# Altium schematic sheet 光源机计时器.SchDoc
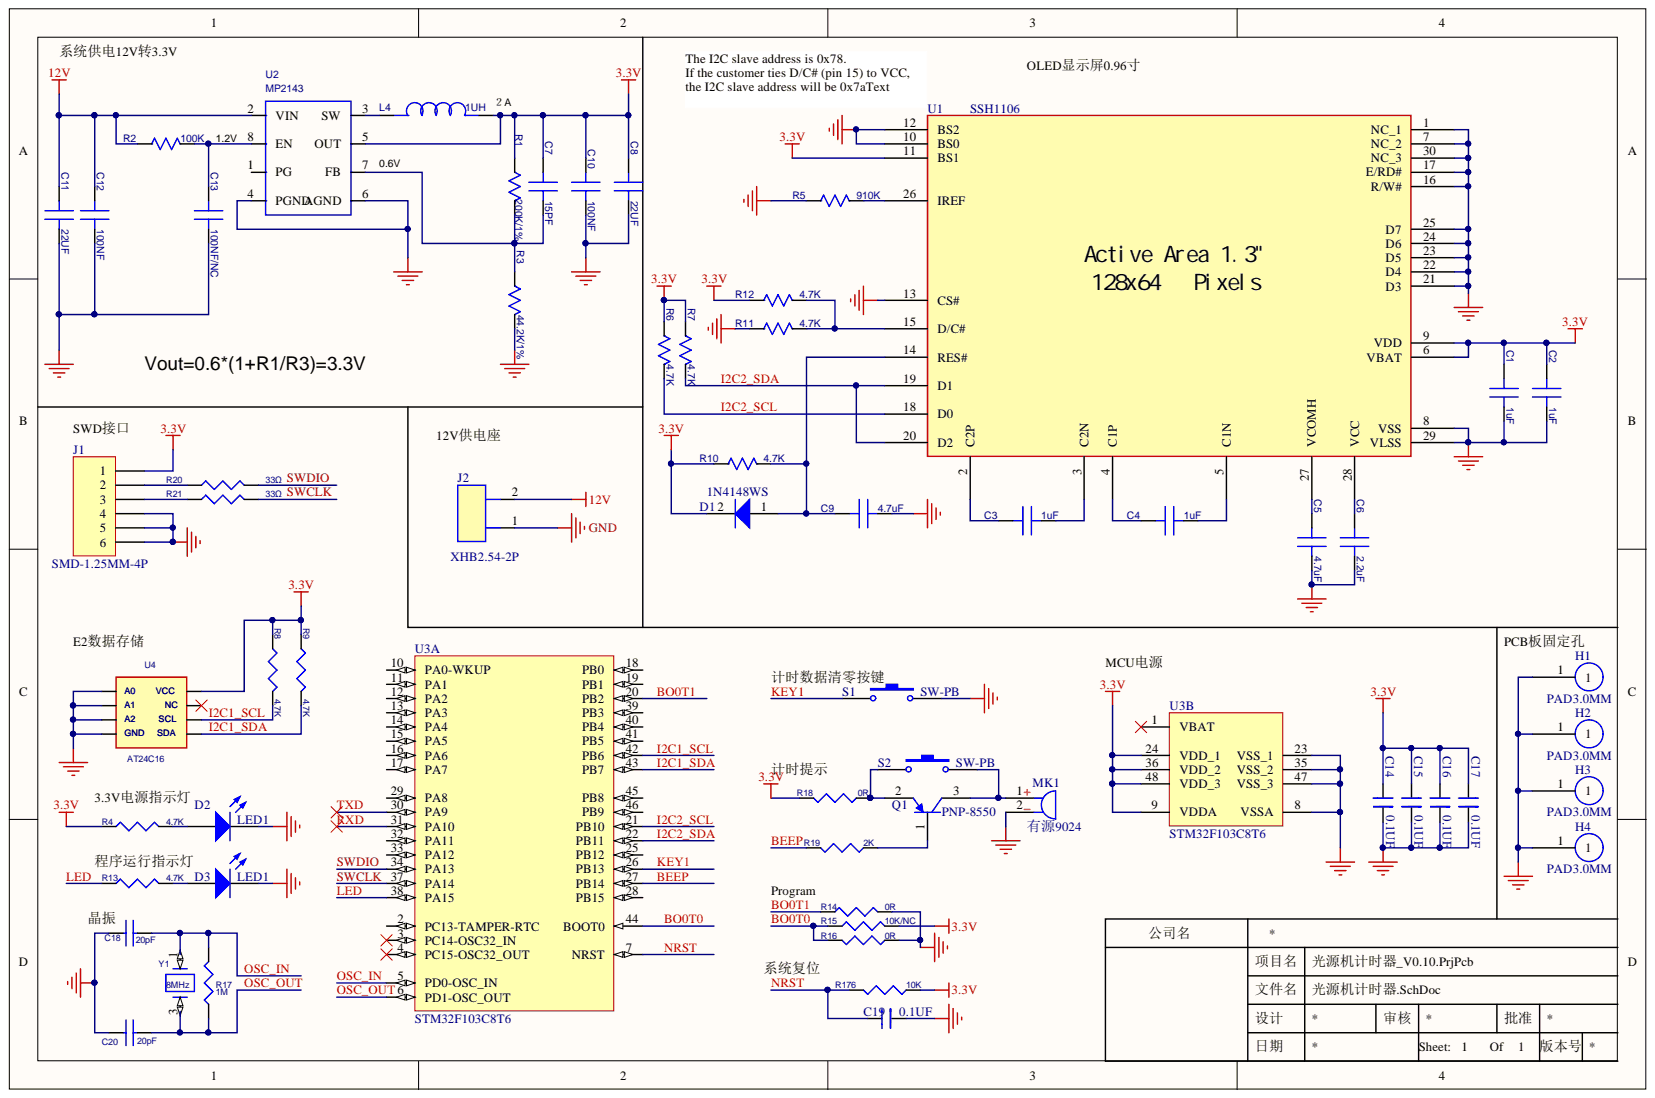
[diagram p0: 光源机计时器.SchDoc]

## Parts
C1  1uF  [0603C]
C2  1uF  [0603C]
C3  1uF  [0603C]
C4  1uF  [0603C]
C5  4.7uF  [0603C]
C6  2.2uF  [0603C]
C7  15PF  [0603C]
C8  22UF  [C0805]
C9  4.7uF  [0603C]
C10  100NF  [0603C]
C11  22UF  [C0805]
C12  100NF  [0603C]
C13  100NF/NC  [0603C]
C14  0.1UF  [0603C]
C15  0.1UF  [0603C]
C16  0.1UF  [0603C]
C17  0.1UF  [0603C]
C18  20pF  [0603C]
C19  0.1UF  [0603C]
C20  20pF  [0603C]
D1  1N4148WS  [SOD323]
D2  LED1  [0603-LED_SMD]
D3  LED1  [0603-LED_SMD]
H1  PAD3.0MM  [3mm]
H2  PAD3.0MM  [3mm]
H3  PAD3.0MM  [3mm]
H4  PAD3.0MM  [3mm]
J1  SMD-1.25MM-4P  [SDM-XH1.25MM-4P]
J2  XHB2.54-2P  [DIP2_2.54mm]
L4  1UH  [SPL0404B]
MK1  有源9024  [hummer 9*2.4]
Q1  PNP-8550  [SOT-23B_N]
R1  200K/1%  [0603R]
R2  100K  [0603R]
R3  44.2K/1%  [0603R]
R4  4.7K  [0603R]
R5  910K  [0603R]
R6  4.7K  [0603R]
R7  4.7K  [0603R]
R8  4.7K  [0603R]
R9  4.7K  [0603R]
R10  4.7K  [0603R]
R11  4.7K  [0603R]
R12  4.7K  [0603R]
R13  4.7K  [0603R]
R14  0R  [0603R]
R15  10K/NC  [0603R]
R16  0R  [0603R]
R17  1M  [0603R]
R18  0R  [0603R]
R19  2K  [0603R]
R20  33Ω  [0603R]
R21  33Ω  [0603R]
R176  10K  [0603R]
S1  SW-PB  [SMD-5*5*1.5]
S2  SW-PB  [SMD-5*5*1.5]
U1  SSH1106  [OLED1.3_P0.7-W0.4]
U2  DEVICE: MP2143  [TSOT23-8]  (hidden comment: MP2161GJ)
U3  STM32F103C8T6  [STM-LQFP48_N]  (parts A,B)
U4  AT24C16  [SO8_N]
Y1  8MHz  [OSC3225]

## Nets
3.3V: C1.1 C2.1 C7.1 C8.1 C10.1 C14.2 C15.2 C16.2 C17.2 D1.2 J1.1 L4.2 R1.1 R4.1 R6.1 R7.1 R8.1 R9.1 R10.1 R12.1 R15.2 R18.2 R176.1 U1.6(VBAT) U1.9(VDD) U1.11(BS1) U2.5(OUT) U3.9(VDDA) U3.24(VDD_1) U3.36(VDD_2) U3.48(VDD_3) U4.8(VCC)
12V: C11.1 C12.1 J2.2 R2.1 U2.2(VIN)
GND: C1.2 C2.2 C5.2 C6.2 C8.2 C9.2 C10.2 C11.2 C12.2 C13.2 C14.1 C15.1 C16.1 C17.1 C18.1 C19.1 C20.1 D2.2 D3.2 H1.1 H2.1 H3.1 H4.1 J1.4 J1.5 J1.6 J2.1 MK1.2 R3.2 R5.1 R11.1 R14.2 R16.1 S1.2 U1.1(NC_1) U1.7(NC_2) U1.8(VSS) U1.10(BS0) U1.12(BS2) U1.13(CS#) U1.16(R/W#) U1.17(E/RD#) U1.21(D3) U1.22(D4) U1.23(D5) U1.24(D6) U1.25(D7) U1.29(VLSS) U1.30(NC_3) U2.4(PGND) U2.6(AGND) U3.8(VSSA) U3.23(VSS_1) U3.35(VSS_2) U3.47(VSS_3) U4.1(A0) U4.2(A1) U4.3(A2) U4.4(GND) Y1.2 Y1.4
BEEP: R19.2 U3.27(PB14)
BO0T0: R15.1 R16.2 U3.44(BOOT0)
BO0T1: R14.1 U3.20(PB2)
I2C1_SCL: R8.2 U3.42(PB6) U4.6(SCL)
I2C1_SDA: R9.2 U3.43(PB7) U4.5(SDA)
I2C2_SCL: R6.2 U1.18(D0) U3.21(PB10)
I2C2_SDA: R7.2 U1.19(D1) U1.20(D2) U3.22(PB11)
KEY1: S1.1 U3.26(PB13)
LED: R13.1 U3.38(PA15)
NRST: C19.2 R176.2 U3.7(NRST)
OSC_IN: C18.2 R17.2 U3.5(PD0-OSC_IN) Y1.1
OSC_OUT: C20.2 R17.1 U3.6(PD1-OSC_OUT) Y1.3
RXD: U3.31(PA10)
SWCLK: R21.1 U3.37(PA14)
SWDIO: R20.1 U3.34(PA13)
TXD: U3.30(PA9)
NetC3_1: C3.1 U1.2(C2P)
NetC3_2: C3.2 U1.3(C2N)
NetC4_1: C4.1 U1.4(C1P)
NetC4_2: C4.2 U1.5(C1N)
NetC5_1: C5.1 U1.27(VCOMH)
NetC6_1: C6.1 U1.28(VCC)
NetC7_2: C7.2 R1.2 R3.1 U2.7(FB)
NetC9_1: C9.1 D1.1 R10.2 U1.14(RES#)
NetC13_1: C13.1 R2.2 U2.8(EN)
NetD2_1: D2.1 R4.2
NetD3_1: D3.1 R13.2
NetJ1_2: J1.2 R20.2
NetJ1_3: J1.3 R21.2
NetL4_1: L4.1 U2.3(SW)
NetMK1_1: MK1.1 Q1.3 S2.2
NetQ1_1: Q1.1 R19.1
NetQ1_2: Q1.2 R18.1 S2.1
NetR5_2: R5.2 U1.26(IREF)
NetR11_2: R11.2 R12.2 U1.15(D/C#)

Not connected: U2 1(PG); U3 1(VBAT) 2(PC13-TAMPER-RTC) 3(PC14-OSC32_IN) 4(PC15-OSC32_OUT) 10(PA0-WKUP) 11(PA1) 12(PA2) 13(PA3) 14(PA4) 15(PA5) 16(PA6) 17(PA7) 18(PB0) 19(PB1) 25(PB12) 28(PB15) 29(PA8) 32(PA11) 33(PA12) 39(PB3) 40(PB4) 41(PB5) 45(PB8) 46(PB9); U4 7(NC)

## Library data of the parts
C1-C6 C9 = C_0: PARTS: 1; %182%%238%%182%%168%%185%%164%%215%%247%%181%%231%%209%%185%(V): 50; %195%%232%%202%%246%: SMD陶瓷电容-50V-100NF-+/-10%-X7R-0603; %208%%161%%192%%224%%195%%251%%179%%198%: SMD陶瓷电容; CODE: 8070627; COMPONENT_HIGH_MAX: 0.9MM; DEVICE: C1608X7R1H104KT000N; LEVEL: STD; OriginalSymbolOrigin: (0,0); PINSWAP: (A,B); PKG_TYPE: SC0603; Component Kind: Standard
C7 = C_0: PARTS: 1; %182%%238%%182%%168%%185%%164%%215%%247%%181%%231%%209%%185%(V): 50; %195%%232%%202%%246%: SMD陶瓷电容-50V-0.015NF-+/-5%-NP0-0402; %208%%161%%192%%224%%195%%251%%179%%198%: SMD陶瓷电容; CODE: 8070544; COMPONENT_HIGH_MAX: 0.55MM; DEVICE: C0402C150J5GAC_7867; LEVEL: STD; OriginalSymbolOrigin: (0,0); PINSWAP: (A,B); PKG_TYPE: SC0402; Component Kind: Standard
C8 = C_0: PARTS: 1; %182%%238%%182%%168%%185%%164%%215%%247%%181%%231%%209%%185%(V): 6.3; %195%%232%%202%%246%: SMD陶瓷电容-6.3V-22000NF-+/-20%-X5R-0805-08070784编码拆分供华为技术使用; %208%%161%%192%%224%%195%%251%%179%%198%: SMD陶瓷电容; CODE: 8071114; COMPONENT_HIGH_MAX: 1.35MM; DEVICE: C2012X5R0J226MT000N; LEVEL: STD; OriginalSymbolOrigin: (0,0); PINSWAP: (A,B); PKG_TYPE: SC0805; Component Kind: Standard
C10 = C_0: PARTS: 1; %182%%238%%182%%168%%185%%164%%215%%247%%181%%231%%209%%185%(V): 16; %195%%232%%202%%246%: SMD陶瓷电容-16V-100NF-+/-10%-X7R-0402-BT; %208%%161%%192%%224%%195%%251%%179%%198%: SMD陶瓷电容; CODE: 8070614; COMPONENT_HIGH_MAX: 0.6MM; DEVICE: RMEMK105BJ104KV_FR; LEVEL: STD; OriginalSymbolOrigin: (0,0); PINSWAP: (A,B); PKG_TYPE: SC0402; Component Kind: Standard
C11 = C_0: PARTS: 1; %182%%238%%182%%168%%185%%164%%215%%247%%181%%231%%209%%185%(V): 6.3; %195%%232%%202%%246%: SMD陶瓷电容-6.3V-22000nF-+/-20%-X5R-0805-08070784编码拆分供华为技术使用; %208%%161%%192%%224%%195%%251%%179%%198%: SMD陶瓷电容; CODE: 8071114; COMPONENT_HIGH_MAX: 1.4mm; DEVICE: C2012X5R0J226MT000N; LEVEL: STD; OriginalSymbolOrigin: (0,0); PINSWAP: (A,B); PKG_TYPE: SC0805; Component Kind: Standard
C12 = C_0: PARTS: 1; %182%%238%%182%%168%%185%%164%%215%%247%%181%%231%%209%%185%(V): 10; %195%%232%%202%%246%: SMD陶瓷电容-10V-100nF-+/-10%-X5R-0402; %208%%161%%192%%224%%195%%251%%179%%198%: SMD陶瓷电容; CODE: 8070561; COMPONENT_HIGH_MAX: 0.55MM; DEVICE: C0402C104K8PAC_7867; LEVEL: STD; OriginalSymbolOrigin: (0,0); PINSWAP: (A,B); PKG_TYPE: SC0402; Component Kind: Standard
C13 = C_0: PARTS: 1; %182%%238%%182%%168%%185%%164%%215%%247%%181%%231%%209%%185%(V): 16; %195%%232%%202%%246%: SMD陶瓷电容-16V-100NF-+/-10%-X7R-0402-BT; %208%%161%%192%%224%%195%%251%%179%%198%: SMD陶瓷电容; CODE: 8070614; COMPONENT_HIGH_MAX: 0.55MM; DEVICE: RMEMK105BJ104KV_FR; LEVEL: STD; OriginalSymbolOrigin: (0,0); PINSWAP: (A,B); PKG_TYPE: SC0402; Component Kind: Standard
C14-C17 C19 = CAP2: 陶瓷电容; Component Kind: Standard; Library Name: 原理图元件.SCHLIB.Lib
C18 C20 = C_Dup1: EDIForiginalOrigin: (pt 0 0); EDIFviewName: C_NORMAL; Implementation Type: <none>; Number devices in Package: 1; Pin Names Rotate: False; PositionInPackage: 0; Source Package: C; Component Kind: Standard; Pin Names Visible: False; Pin Numbers Visible: False
D1 = Diode: Default Diode; Component Kind: Standard; Library Name: Miscellaneous Devices.IntLib
D2 D3 = LED2: Typical RED, GREEN, YELLOW, AMBER GaAs LED; Component Kind: Standard; Library Name: Miscellaneous Devices.IntLib
J1 = Header 6: Header, 6-Pin; Component Kind: Standard; Library Name: Miscellaneous Connectors.IntLib
J2 = 2P: Component Kind: Standard; Library Name: 自己元件.SCHLIB.Lib
L4 = L_1: PARTS: 1; %195%%232%%202%%246%: 贴片电感器-1UH-30%-0.0324OHM-4A-40DEGC-4*4*2MM; %208%%161%%192%%224%%195%%251%%179%%198%: 贴片电感器; CODE: 10031357; COMPONENT_HIGH_MAX: 2.1MM; DEVICE: NRS4018T1R0NDGJ; LEVEL: STD; OriginalSymbolOrigin: (-20,0); Component Kind: Standard; PKG_TYPE: SPL0404B
MK1 = Mic1: Microphone; Component Kind: Standard; Library Name: Miscellaneous Devices.IntLib
Q1 = PNP: PNP Bipolar Transistor; Component Kind: Standard; Library Name: Miscellaneous Devices.IntLib
R1 R3 = R_0: PARTS: 1; %182%%238%%182%%168%%185%%166%%194%%202%(W): 0.1; %195%%232%%202%%246%: 片式厚膜电阻器-0.1W-200000OHM-+/-1%-0603; %208%%161%%192%%224%%195%%251%%179%%198%: 片式厚膜电阻器; %215%%232%%214%%181%%212%%202%%208%%237%%198%%171%%178%%238%: +/-1%; CODE: 7091263; COMPONENT_HIGH_MAX: 0.55MM; DEVICE: RK73H1JTTD2003F; LEVEL: STD; OriginalSymbolOrigin: (0,0); PINSWAP: (A,B); PKG_TYPE: SR0603; Component Kind: Standard
R2 = R_0: PARTS: 1; %182%%238%%182%%168%%185%%166%%194%%202%(W): 0.06; %195%%232%%202%%246%: 片式厚膜电阻器-0.0625W-100000OHM-+/-1%-0402; %208%%161%%192%%224%%195%%251%%179%%198%: 片式厚膜电阻器; %215%%232%%214%%181%%212%%202%%208%%237%%198%%171%%178%%238%: +/-1%; CODE: 7090875; COMPONENT_HIGH_MAX: 0.4MM; DEVICE: RK73H1ETTP1003F; LEVEL: STD; OriginalSymbolOrigin: (0,0); PINSWAP: (A,B); PKG_TYPE: SR0402; Component Kind: Standard
R4 R8 R9 R13-R17 R176 = R_Dup2: EDIForiginalOrigin: (pt 0 0); EDIFviewName: R_NORMAL; Implementation Type: <none>; Number devices in Package: 1; Pin Names Rotate: True; Pin Names Visible: False; PositionInPackage: 0; Source Package: R; Component Kind: Standard; Pin Numbers Visible: False
R5-R7 R10-R12 = R_0: PARTS: 1; %182%%238%%182%%168%%185%%166%%194%%202%(W): 0.1; %195%%232%%202%%246%: 片式厚膜电阻器-0.1W-33200OHM-+/-1%-0603; %208%%161%%192%%224%%195%%251%%179%%198%: 片式厚膜电阻器; %215%%232%%214%%181%%212%%202%%208%%237%%198%%171%%178%%238%: +/-1%; CODE: 7090766; COMPONENT_HIGH_MAX: 0.55MM; DEVICE: RC0603FR_0733K2; LEVEL: STD; OriginalSymbolOrigin: (0,0); PINSWAP: (A,B); PKG_TYPE: SR0603; Component Kind: Standard
R18 R19 = R_Dup2: Component Kind: Standard
R20 R21 = R_Dup5: Component Kind: Standard
S1 S2 = SW-PB: Switch; Component Kind: Standard; LatestRevisionDate: 17-Jul-2002; LatestRevisionNote: Re-released for DXP Platform.; Library Name: Miscellaneous Devices.IntLib; PackageDescription: Switch; 2 Leads; PackageReference: SPST-2; Published: 24-Mar-1999; Publisher: Altium Limited
U1 = SSD1306: Component Kind: Standard; Library Name: 光源机计时器.SchLib
U2 = MP2161GJ_0: PARTS: 1; %195%%232%%202%%246%: MP2161GJ-01754725; CODE: 99054LFT; COMPONENT_HIGH_MAX: 1MM; LEVEL: STD; OriginalSymbolOrigin: (0,0); Component Kind: Standard; PKG_TYPE: TSOT23-8
U3 = STM32F103C8T6: ARM Cortex-M3 32-bit MCU, 64 KB Flash, 20 KB Internal RAM, 37 I/Os, 48-pin LQFP, -40 to 85 degC, Tray; A/D Converter: 2x12-bit (10 Channel); FLASH Size (Prog) (kB): 64; I/Os (High Current): 37; Internal RAM Size (kB): 20; Operating Frequency(F) (Processor speed) (MHz): 72; Supply Voltage(Vcc) min (V): 2; Component Kind: Standard; ComponentLink1Description: Manufacturer URL; ComponentLink1URL: http://www.st.com/web/en/home.html; ComponentLink2Description: Datasheet; ComponentLink2URL: http://www.st.com/st-web-ui/static/active/en/resource/technical/document/datasheet/CD00161566.pdf; ComponentLink3Description: Package Specification; ComponentLink3URL: http://www.st.com/st-web-ui/static/active/en/resource/technical/document/datasheet/DM00058181.pdf; Core: ARM Cortex-M3; DatasheetVersion: Rev. 16; Library Name: STMicroelectronics STM32 F1.IntLib; Mounting Technology: Surface Mount; PackageDescription: 48-Pin Low Profile Quad Flat Package, 7 x 7 mm Body, 0.5 mm Pitch; PackageReference: LQFP48; PackageVersion: Rev. 6, 06/2013; Packing: Tray; PartNumber: STM32F103C8T6; Supplier 1: Digi-Key; Supplier 2: Farnell; Supplier 3: Newark; Supplier 4: Newark; Supplier 5: Mouser; Supplier Part Number 1: 497-6063-ND; Supplier Part Number 2: 1447637; Supplier Part Number 3: 59M2182; Supplier Part Number 4: 57P1222; Supplier Part Number 5: 511-STM32F103C8T6
U4 = AT24C02: EDIForiginalOrigin: (pt 0 0); EDIFviewName: AT24C02_NORMAL; Implementation Type: <none>; Number devices in Package: 1; Pin Names Rotate: True; PositionInPackage: 0; Component Kind: Standard; Pin Names Visible: True; Pin Numbers Visible: True; Source Package: AT24C02
Y1 = CRYSTAL_4PIN: Part Description: 4 pin, passive; Designer: Hujin; Component Kind: Standard; Create Date: 20130122; Part Number: 647-00-3038
H1-H4 = PAD3.0MM: Component Kind: Standard; Library Name: 原理图元件.SCHLIB.Lib
